# Altium schematic sheet audio_speaker_dac_daughtercard.SchDoc
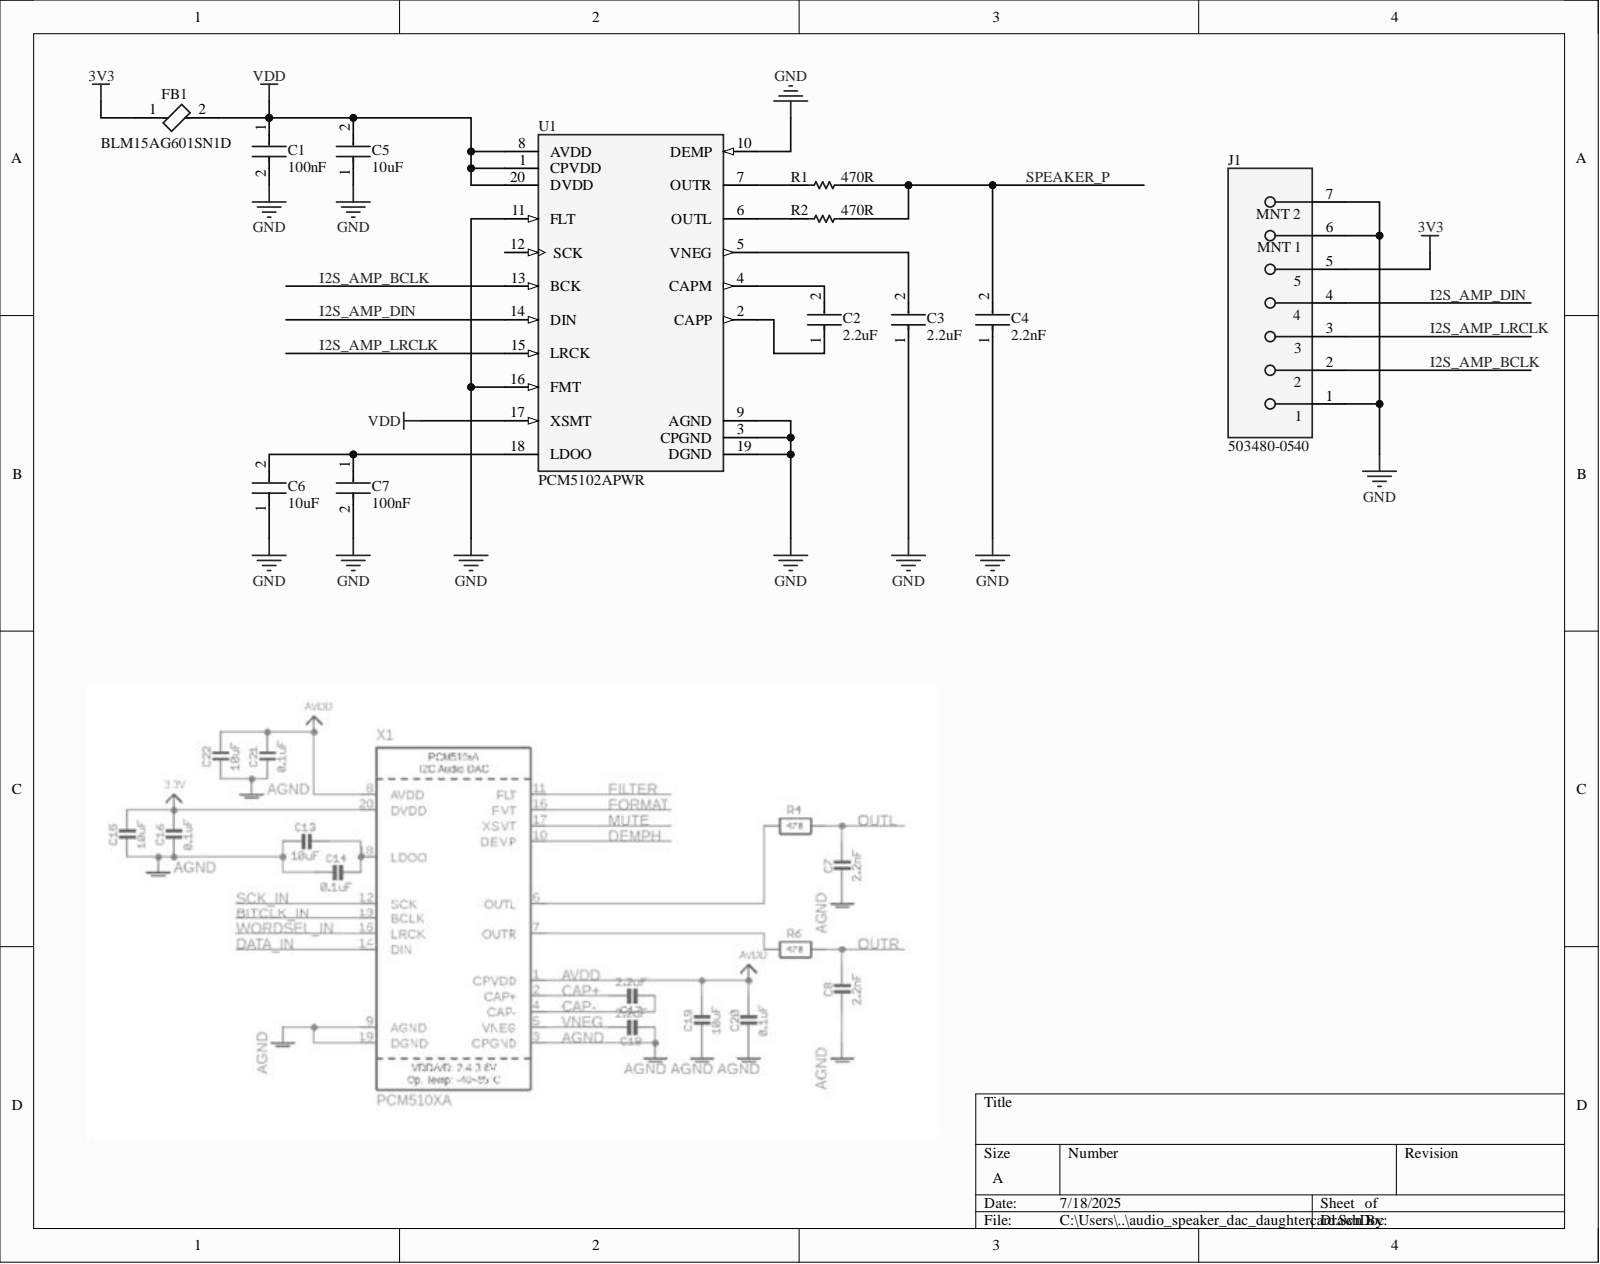
[diagram p0: audio_speaker_dac_daughtercard.SchDoc]

## Parts
C1  Capacitance: 100nF  [FP-GRM15-MFG]  (hidden comment: GRM155R71C104KA88D)
C2  Capacitance: 2.2uF  [FP-GRM155-0_05-MFG]  (hidden comment: GRM155R61A225KE95D)
C3  Capacitance: 2.2uF  [FP-GRM155-0_05-MFG]  (hidden comment: GRM155R61A225KE95D)
C4  Capacitance: 2.2nF  [FP-0402-L_1_0_1-W_0_5_0_1-MFG]  (hidden comment: 04025C222KAT2A)
C5  value 10uF  Capacitance: 10uF  [FP-CL05A106MP5NUNC-MFG]  (hidden comment: CL05A106MP5NUNC)
C6  value 10uF  Capacitance: 10uF  [FP-CL05A106MP5NUNC-MFG]  (hidden comment: CL05A106MP5NUNC)
C7  Capacitance: 100nF  [FP-GRM15-MFG]  (hidden comment: GRM155R71C104KA88D)
FB1  BLM15AG601SN1D  [FP-BLM15-0_05-IPC_B]
J1  503480-0540  [FP-503480-0540-MFG]
R1  value 470R  [RESC1005X40X25ML05T10]  (hidden comment: RC0402FR-07470RL)
R2  value 470R  [RESC1005X40X25ML05T10]  (hidden comment: RC0402FR-07470RL)
U1  PCM5102APWR  [FP-PW0020A-IPC_A]

## Nets
3V3: FB1.1 J1.5
GND: C1.2 C3.1 C4.1 C5.1 C6.1 C7.2 J1.1 J1.6 J1.7 U1.3(CPGND) U1.9(AGND) U1.10(DEMP) U1.11(FLT) U1.16(FMT) U1.19(DGND)
VDD: C1.1 C5.2 FB1.2 U1.1(CPVDD) U1.8(AVDD) U1.17(XSMT) U1.20(DVDD)
I2S_AMP_BCLK: J1.2 U1.13(BCK)
I2S_AMP_DIN: J1.4 U1.14(DIN)
I2S_AMP_LRCLK: J1.3 U1.15(LRCK)
SPEAKER_P: C4.2 R1.1 R2.1
NetC2_1: C2.1 U1.2(CAPP)
NetC2_2: C2.2 U1.4(CAPM)
NetC3_2: C3.2 U1.5(VNEG)
NetC6_2: C6.2 C7.1 U1.18(LDOO)
NetR1_2: R1.2 U1.7(OUTR)
NetR2_2: R2.2 U1.6(OUTL)

Not connected: U1 12(SCK)

## Library data of the parts
C1 C7 = CMP-2100-03641-2: CAP CER 0.1UF 16V X7R 0402; Manufacturer: Murata; Material: Ceramic; Standoff Height: 0mm; Tolerance: 10%; Value: 0.10μF; Voltage Rating: 16Vdc; Category: Cap; Component Kind: Standard; ComponentLink2Description: Datasheet; ComponentLink2URL: https://search.murata.co.jp/Ceramy/image/img/A01X/G101/ENG/GRM155R71H471KA01-01A.pdf; Device Class L1: Passive Components; Device Class L2: Capacitors; Device Class L3: Ceramic Capacitors; Digikey Description: CAP CER 0.1UF 16V X7R 0402; Height: 0.55mm; Ipc Land Pattern Name: CAPC10050X219; Lead Free: yes; Library Name: Altium Content Vault; Manufacturer Part Number: GRM155R71C104KA88D; Mouser Description: 0402 0.1 uF 16V ±10 % Tolerance X7R SMT Multilayer Ceramic Capacitor; Octopart: https://octopart.com/grm155r71c104ka88d-murata-196880; Package: GRM15; RoHS: TRUE; Temperature: -55°C to +125°C; Temperature Characteristic: X7R; Temperature Range High: +125°C; Temperature Range Low: -55°C
C2 C3 = CMP-06035-063009-1: CAP CER 2.2UF 10V X5R 0402; Material: Ceramic; Tolerance: 10%; Case Code (Imperial): 0402; Case Code (Metric): 1005; Case/Package: 0402; Component Kind: Standard; Depth: 0.5mm; Dielectric: X5R; Height: 0.5mm; Lead Free: Lead Free; Length: 1mm; Library Name: Altium Content Vault; Max Operating Temperature: 85°C; Min Operating Temperature: -55°C; Mount: Surface Mount; Package Quantity: 10000; Packaging: Tape and Reel; REACH SVHC: No SVHC; RoHS Compliant: Yes; Termination: SMD/SMT; Thickness: 0.022inch; Voltage Rating: 10V; Voltage Rating (DC): 10V; Weight: 0.000212oz; Width: 0.5mm
C4 = CMP-2008-02711-2: General Purpose Ceramic Capacitor, 0402, 2.2nF, 10%, X7R, 15%, 50V; Case Code (Imperial): 0402; Case Code (Metric): 1005; Case/Package: 0402; Component Kind: Standard; Construction: Flat; Contact Plating: Tin; Depth: 0.5mm; Dielectric: X7R; Dielectric Material: Ceramic; Height: 0.56mm; Lead Free: Lead Free; Length: 1mm; Library Name: Altium Content Vault; Max Operating Temperature: 125°C; Min Operating Temperature: -55°C; Mount: Surface Mount; Number of Pins: 2; Package Quantity: 1; Packaging: Tape and Reel; Radiation Hardening: No; REACH SVHC: No SVHC; RoHS Compliant: Yes; Series: -; Termination: SMD/SMT; Thickness: 0.022inch; Tolerance: 10%; Voltage: 50V; Voltage Rating: 50V; Voltage Rating (DC): 50V; Width: 0.02inch
C5 C6 = CMP-13271-000002-1: CAP CER 10UF 10V X5R 0402; Manufacturer: Samsung; Material: Ceramic; Temperature Coefficient: 15%; Tolerance: 20%; Automotive: No; Category: Cap; Component Kind: Standard; ComponentLink2Description: Datasheet; ComponentLink2URL: http://www.samsungsem.com/kr/support/product-search/mlcc/__icsFiles/afieldfile/2019/05/10/19-CL05A106MP5NUNC.pdf; Device Class L1: Passive Components; Device Class L2: Capacitors; Device Class L3: Ceramic Capacitors; Digikey Description: CAP CER 10UF 10V X5R 0402; Height: 0.7mm; Ipc Land Pattern Name: CAPC10050X50; Lead Free: Yes; Library Name: Altium Content Vault; Manufacturer Part Number: CL05A106MP5NUNC; Octopart: https://octopart.com/cl05a106mp5nunc-samsung-22457395; Package: CL05A106MP5NUNC; RoHS: TRUE; Temperature: -55°C to +85°C; Temperature Characteristic: X5R; Temperature Range High: +85°C; Temperature Range Low: -55°C; Voltage Rating: 10V
FB1 = CMP-0686-00187-4: Chip Ferrite Bead, 0402, 600Ω @ 100MHz, 0.52Ω, 25%, 300mA; DC Resistance (DCR): 520mR; DC Resistance-Max: 600mR; Impedance: 600R; Material: Steel; Number of Circuits: 1; Shielding: Unshielded; Case Code (Imperial): 0402; Case Code (Metric): 1005; Case/Package: 0402; Component Kind: Standard; Core Material: Ferrite; Current Rating: 300mA; DC Current: 300mA; Depth: 0.55mm; Frequency: 100MHz; Height: 0.5mm; Lead Free: Lead Free; Length: 1mm; Library Name: Altium Content Vault; Max Current Rating: 300mA; Max DC Current: 300mA; Max Operating Temperature: 125°C; Military Standard: Not; Min Operating Temperature: -55°C; Number of Lines: 1; Number of Pins: 2; Package Quantity: 10000; Packaging: Tape and Reel; Radiation Hardening: No; REACH SVHC: No SVHC; RoHS Compliant: Yes; Series: BLM15AG_SN; Termination: SMD/SMT; Test Frequency: 100MHz; Tolerance: 25%; Width: 0.5mm
J1 = CMP-00257-52963780-1: 503480-0540 Connector FFC/FPC SKT 5 Position 0.5mm Solder Right Angle Surface Mount T/R; Manufacturer Lifecycle Status: ACTIVE; Actuator Material: Glass, Nylon, Polyamide; Current Rating: 500mA; Number of Circuits: 5; Pitch: 508µm; Plating: Gold; Voltage Rating: 50V; Wire/Cable Type: Straight; Component Kind: Standard; Contact Material: Bronze, Gold, Nickel; Contact Plating: Gold; Country of Origin: KR; Flammability Rating: UL94 V-0; Halogen Free: Low Halogen; Height: 2.07mm; Housing Material: Polymer; Library Name: Altium Content Vault; Lifecycle Status: Production; Max Operating Temperature: 85°C; Min Operating Temperature: -40°C; Mount: Surface Mount; NexarPartId: 52963780; Number of Contacts: 5; Number of Positions: 5; Number of Rows: 1; Orientation: Right Angle; RoHS: Compliant; Termination: Solder
R1 R2 = CMP-2002-07697-1: Manufacturer: Yageo / Phycomp; Component Kind: Standard; Library Name: Altium Content Vault; Part Number: RC0402FR-07470RL
U1 = CMP-04861-000013-1: IC DAC 16/24/32BIT 384K 20TSSOP; Conversion Rate: 384KS/s; Interface: Serial; Max Power Dissipation: 187mW; Max Supply Voltage: 3.6V; Min Supply Voltage: 3V; Number of Analog Inputs: 0; Number of Analog Outputs: 2; Number of Bits: 32; Operating Supply Voltage: 3.3V; Output Type: Voltage; Polarity: Unipolar; Power Consumption: 59.4mW; Resolution: 32b; Signal to Noise Ratio (SNR): 112dB; Supply Type: Analog; Case/Package: TSSOP; Component Kind: Standard; Contact Plating: Gold; Lead Free: Lead Free; Library Name: Altium Content Vault; Max Operating Temperature: 85°C; Min Operating Temperature: -25°C; Mount: Surface Mount; Number of Converters: 2; Number of DAC Channels: 2; Number of Pins: 20; Package Quantity: 2000; Packaging: Tape and Reel; REACH SVHC: No SVHC; Sampling Rate: 384KS/s; Series: DirectPath™
